# Altium schematic sheet BMS_Battery_Connections.SchDoc
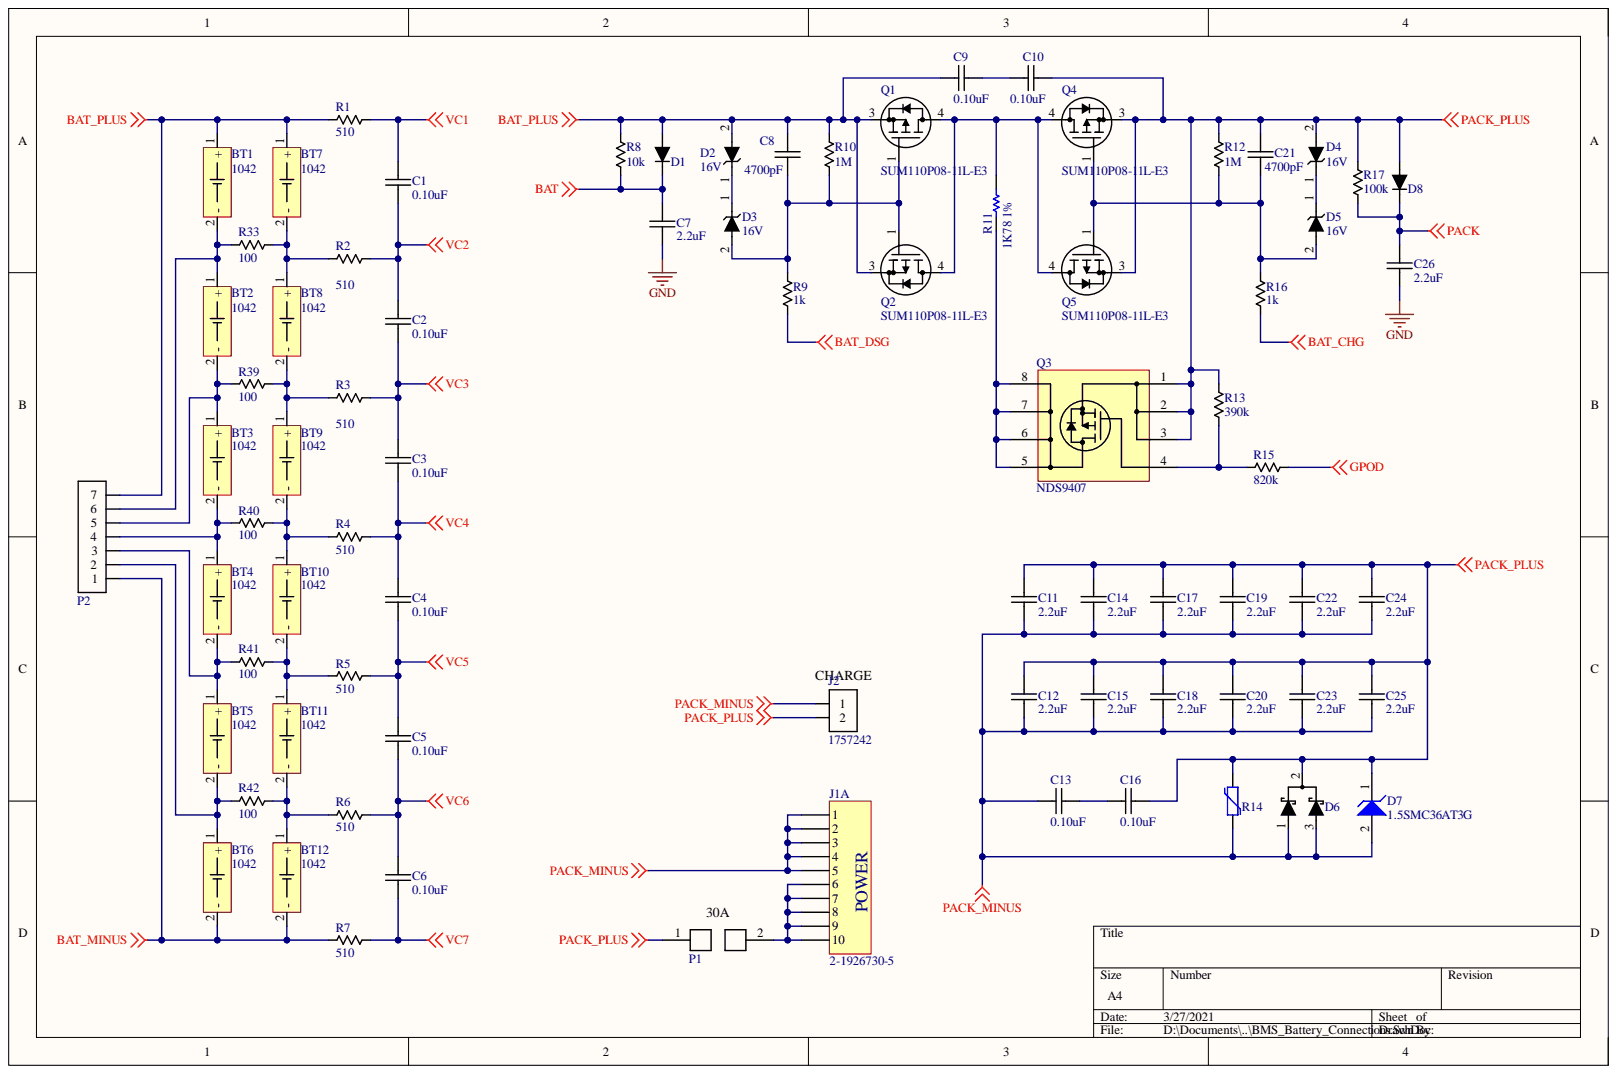
[diagram p0: BMS_Battery_Connections.SchDoc]

## Parts
BT1  1042  [KEYSTONE_1042]
BT2  1042  [KEYSTONE_1042]
BT3  1042  [KEYSTONE_1042]
BT4  1042  [KEYSTONE_1042]
BT5  1042  [KEYSTONE_1042]
BT6  1042  [KEYSTONE_1042]
BT7  1042  [KEYSTONE_1042]
BT8  1042  [KEYSTONE_1042]
BT9  1042  [KEYSTONE_1042]
BT10  1042  [KEYSTONE_1042]
BT11  1042  [KEYSTONE_1042]
BT12  1042  [KEYSTONE_1042]
C1  0.10uF  [chip_0805]
C2  0.10uF  [chip_0805]
C3  0.10uF  [chip_0805]
C4  0.10uF  [chip_0805]
C5  0.10uF  [chip_0805]
C6  0.10uF  [chip_0805]
C7  2.2uF  [chip_1210]
C8  4700pF  [chip_0805]
C9  0.10uF  [chip_0805]
C10  0.10uF  [chip_0805]
C11  2.2uF  [chip_1210]
C12  2.2uF  [chip_1210]
C13  0.10uF  [chip_0805]
C14  2.2uF  [chip_1210]
C15  2.2uF  [chip_1210]
C16  0.10uF  [chip_0805]
C17  2.2uF  [chip_1210]
C18  2.2uF  [chip_1210]
C19  2.2uF  [chip_1210]
C20  2.2uF  [chip_1210]
C21  4700pF  [chip_0805]
C22  2.2uF  [chip_1210]
C23  2.2uF  [chip_1210]
C24  2.2uF  [chip_1210]
C25  2.2uF  [chip_1210]
C26  2.2uF  [chip_1210]
D1  diode_0  [sod123_0]  (value not drawn)
D2  16V  [sod523_0]
D3  16V  [sod523_0]
D4  16V  [sod523_0]
D5  16V  [sod523_0]
D6  V40PWM10C-M3/I  [DPAK_SLIM]  (value not drawn)
D7  1.5SMC36AT3G  [ONSC-SMC-2-403-03_V]
D8  diode_0  [sod123_0]  (value not drawn)
J1  2-1926730-5  [TE_CONN_2-1926730-5]  (part A)
J2  1757242  [PHOENIX_1757242]
P1  conn-fuse-holder_0  [FUSE_HOLDER_KEYSTONE_3557-2]  (value not drawn)
P2  conn-7x1_0  [HDDR_7x1_0]  (value not drawn)
Q1  SUM110P08-11L-E3  [D2PAK-3_1]
Q2  SUM110P08-11L-E3  [D2PAK-3_1]
Q3  NDS9407  [SO-8]
Q4  SUM110P08-11L-E3  [D2PAK-3_1]
Q5  SUM110P08-11L-E3  [D2PAK-3_1]
R1  510  [chip_0805]
R2  510  [chip_0805]
R3  510  [chip_0805]
R4  510  [chip_0805]
R5  510  [chip_0805]
R6  510  [chip_0805]
R7  510  [chip_0805]
R8  10k  [chip_0805]
R9  1k  [chip_0805]
R10  1M  [chip_0805]
R11  1K78 1%  [RESC2512(6432)_L]
R12  1M  [chip_0805]
R13  390k  [chip_0805]
R14  MOV_0  [chip_1210]  (value not drawn)
R15  820k  [chip_0805]
R16  1k  [chip_0805]
R17  100k  [chip_0805]
R33  100  [chip_0805]
R39  100  [chip_0805]
R40  100  [chip_0805]
R41  100  [chip_0805]
R42  100  [chip_0805]

## Nets
BAT: C7.1 D1.1 R8.2
BAT_CHG: R16.2
BAT_DSG: R9.2
BAT_MINUS: BT6.2 BT12.2 P2.1 R7.1
BAT_PLUS: BT1.1 BT7.1 C8.1 C9.1 D1.2 D2.2 P2.7 Q1.3 Q2.3 R1.1 R8.1 R10.1
GND: C7.2 C26.2
GPOD: R15.2
PACK: C26.1 D8.1 R17.2
PACK_MINUS: C11.2 C12.2 C13.2 C14.2 C15.2 C17.2 C18.2 C19.2 C20.2 C22.2 C23.2 C24.2 C25.2 D6.1 D6.3 D7.2 J1.1 J1.2 J1.3 J1.4 J1.5 J2.1 R14.1
PACK_PLUS: C10.2 C11.1 C12.1 C14.1 C15.1 C16.1 C17.1 C18.1 C19.1 C20.1 C21.1 C22.1 C23.1 C24.1 C25.1 D4.2 D6.2 D7.1 D8.2 J2.2 P1.1 Q3.1 Q3.2 Q3.3 Q4.3 Q5.3 R12.1 R13.1 R14.2 R17.1
VC1: C1.1 R1.2
VC2: C1.2 C2.1 R2.2
VC3: C2.2 C3.1 R3.2
VC4: C3.2 C4.1 R4.2
VC5: C4.2 C5.1 R5.2
VC6: C5.2 C6.1 R6.2
VC7: C6.2 R7.2
NetBT1_2: BT1.2 BT2.1 P2.6 R33.1
NetBT2_2: BT2.2 BT3.1 P2.5 R39.1
NetBT3_2: BT3.2 BT4.1 P2.4 R40.1
NetBT4_2: BT4.2 BT5.1 P2.3 R41.1
NetBT5_2: BT5.2 BT6.1 P2.2 R42.1
NetBT7_2: BT7.2 BT8.1 R2.1 R33.2
NetBT8_2: BT8.2 BT9.1 R3.1 R39.2
NetBT9_2: BT9.2 BT10.1 R4.1 R40.2
NetBT10_2: BT10.2 BT11.1 R5.1 R41.2
NetBT11_2: BT11.2 BT12.1 R6.1 R42.2
NetC8_2: C8.2 D3.2 Q1.1 Q2.1 R9.1 R10.2
NetC9_2: C9.2 C10.1
NetC13_1: C13.1 C16.2
NetC21_2: C21.2 D5.2 Q4.1 Q5.1 R12.2 R16.1
NetD2_1: D2.1 D3.1
NetD4_1: D4.1 D5.1
NetJ1_6: J1.6 J1.7 J1.8 J1.9 J1.10 P1.2
NetQ1_4: Q1.4 Q2.4 Q4.4 Q5.4 R11.2
NetQ3_4: Q3.4 R13.2 R15.1
NetQ3_5: Q3.5 Q3.6 Q3.7 Q3.8 R11.1

## Library data of the parts
BT1-BT12 = CONN-00077: Battery Holder, 18650, SMD; Manufacturer: Keystone; Levels: 1; Positions per level: 2; Component Kind: Standard; Cost: 2.76; Library Name: Connectors$.IntLib; Manufacturer PN: 1042; Part Number: CONN-00077; Type: Battery Holder
C1-C6 C9 C10 C13 C16 = CAP-00072: CAP, 0.10uF, 10%, 50V, X7R, 0805; Manufacturer: Murata; Manufacturer PN: GRM21BR71H104KA01K; Capacitance: 0.10uF; Component Kind: Standard; Library Name: Capacitors$.IntLib; Part Number: CAP-00072; Tolerance: 10%; Type: X7R; Voltage Rating: 50V
C7 C11 C12 C14 C15 C17-C20 C22-C26 = CAP-00536: CAP, 2.2uF, ±20%, 100V, X7R, 0603; Manufacturer: TDK; Manufacturer PN: C3225X7R2A225M230AB; Capacitance: 2.2uF; Component Kind: Standard; Cost: $0.88; Library Name: Capacitors$.IntLib; Part Number: CAP-00536; Tolerance: ±20%; Type: X7R; Voltage Rating: 100V
C8 C21 = CAP-00032: CAP, 4700pF, 10%, 100V, X7R, 0805; Manufacturer: Murata; Manufacturer PN: GRM219R72A472KA01D; Capacitance: 4700pF; Component Kind: Standard; Library Name: Capacitors$.IntLib; Part Number: CAP-00032; Tolerance: 10%; Type: X7R; Voltage Rating: 100V
D1 D8 = DIO-00060: Dio, Silicon, 200mA, 1V, 100V, SOD-123; Manufacturer: ON Semi; Manufacturer PN: SMMSD4148T3G; Forward Voltage: 1.0V; Component Kind: Standard; Cost: 0.38; Current Rating: 200mA; Library Name: Diodes$.IntLib; Part Number: DIO-00060; Reverse Breakdown Voltage: 100V; Type: Silicon
D2-D5 = DIO-00082: Dio, Zener, 16V 300mW, SOD-523; Manufacturer: Nexperia; Manufacturer PN: BZX585-C16,115; Current Rating: 100mA; Forward Voltage: 1.1V; Tolerance: 5%; Component Kind: Standard; Cost: 0.25; Library Name: Diodes$.IntLib; Part Number: DIO-00082; Power Rating: 300mW; Reverse Breakdown Voltage: 16V; Type: Zener
D6 = DIO-00081: Dio, Schottky Array, Dual, Common Cathode, 100V 20A, SLIM DPAK; Manufacturer: Vishay; Forward Voltage: 0.89V; Component Kind: Standard; Cost: 1.37; Current Rating: 20A; Library Name: Diodes$.IntLib; Manufacturer PN: V40PWM10C-M3/I; Part Number: DIO-00081; Reverse Breakdown Voltage: 100V; Type: Schottky
D7 = CMP-1061-00497-1: 1500 W Peak Power Zener Transient Voltage Suppressor, Unidirectional, 2-Pin SMC, Pb-Free, Tape and Reel; Manufacturer: On Semiconductor; IR Max (uA): 5; PPK Max (W): 1500; V(BR) Typ (V): 36; Component Kind: Standard; ComponentLink1Description: Manufacturer URL; ComponentLink1URL: http://www.onsemi.com/; ComponentLink2Description: Datasheet; ComponentLink2URL: http://www.onsemi.com/pub_link/Collateral/1.5SMC6.8AT3-D.PDF; ComponentLink3Description: Package Specification; ComponentLink3URL: http://www.onsemi.com/pub_link/Collateral/403-03.PDF; DatasheetVersion: Rev. 10; Direction: Unidirectional; Library Name: Altium Content Vault; Mounting Technology: Surface Mount; PackageDescription: 2-Pin Small Molded Package, J-Bend Lead, Body 5.845 x 6.855 mm; PackageReference: SMC-2-403-03; PackageVersion: Rev. E, 08/2005; Packing: Tape and Reel; PartNumber: 1.5SMC36AT3G; RoHS: Pb-Free
J1 = CONN-00093: Backplane Header, Blades and Pins, Edge Mount Right Angle, 10 Power 25 Signal; Manufacturer: TE Connectivity; Levels: 1; Positions per level: 35; Component Kind: Standard; Cost: 11.68; Library Name: Connectors$.IntLib; Manufacturer PN: 2-1926730-5; Part Number: CONN-00093; Type: Header
J2 = CONN-00102: Terminal Blk Header, 2 Pos, 5.08mm, 12A, Right-Angle; Manufacturer: Phoenix Contact; Levels: 1; Positions per level: 2; Component Kind: Standard; Cost: 0.64; Library Name: Connectors$.IntLib; Manufacturer PN: 1757242; Part Number: CONN-00102; Type: Terminal Block
P1 = CONN-00015: Fuse Holder, 30A, 500V, LP-Mini 897, ATOF 257; Manufacturer: Keystone Electronics; Manufacturer PN: 3557-2; Current: 30; Levels: 1; Positions per level: 2; Component Kind: Standard; Cost: 1.03; Library Name: Connectors$.IntLib; Part Number: CONN-00015
P2 = CONN-00105: Header, 7x1, 0.1"; Manufacturer: Samtec; Manufacturer PN: TSW-107-07-F-S; Levels: 1; Positions per level: 7; Component Kind: Standard; Cost: 0.61; Library Name: Connectors$.IntLib; Part Number: CONN-00105; Type: Header
Q1 Q2 Q4 Q5 = XSTR-00050: XSTR, MOSFET P-Channel, 80V 110A, D2PAK; Manufacturer: Vishay Siliconix; Max Power: 375W; Type: PMOS; Vgs/Vce: 3V; Component Kind: Standard; Cost: 4.43; Library Name: Transistors$.IntLib; Manufacturer PN: SUM110P08-11L-E3; Max Current: 110A; Max Voltage: 80V; Part Number: XSTR-00050
Q3 = XSTR-00036: XSTR PFET, 3A, 60V, 2.5W, SOIC-8; Manufacturer: ON Semi; Vgs/Vce: 1.6V; Component Kind: Standard; Cost: 0.78; Library Name: Transistors$.IntLib; Manufacturer PN: NDS9407; Max Current: 3A; Max Power: 2.5W; Max Voltage: 60V; Part Number: XSTR-00036; Type: PFET
R1-R7 = RES-00240: RES SMD 510 OHM 1% 1/8W 0805; Manufacturer: Rohm Semiconductor; Manufacturer PN: KTR10EZPF5100; Power Rating: 0.125W; Component Kind: Standard; Library Name: Resistors$.IntLib; Part Number: RES-00240; Resistance: 510; Tolerance: 1%
R8 = RES-00307: RES SMD 10K OHM 1% 1/8W 0805; Manufacturer: Rohm Semiconductor; Manufacturer PN: KTR10EZPF1002; Power Rating: 0.125W; Component Kind: Standard; Library Name: Resistors$.IntLib; Part Number: RES-00307; Resistance: 10k; Tolerance: 1%
R9 R16 = RES-00361: RES SMD 1K OHM 1% 1/8W 0805; Manufacturer: Rohm Semiconductor; Manufacturer PN: KTR10EZPF1001; Power Rating: 0.125W; Component Kind: Standard; Library Name: Resistors$.IntLib; Part Number: RES-00361; Resistance: 1k; Tolerance: 1%
R10 R12 = RES-00363: RES SMD 1M OHM 1% 1/8W 0805; Manufacturer: Rohm Semiconductor; Manufacturer PN: KTR10EZPF1004; Power Rating: 0.125W; Component Kind: Standard; Library Name: Resistors$.IntLib; Part Number: RES-00363; Resistance: 1M; Tolerance: 1%
R11 = CMP-1018-00536-1: 1K78 1W 1% 2512 (6432 Metric)  SMD; Case-EIA: 2512; Case-Metric: 6432; Value: 1780.00 Ohm; Component Kind: Standard; Library Name: Altium Content Vault; Power: 1 W; Technology: SMT; Tolerance: 1 %
R13 = RES-00455: RES SMD 390K OHM 1% 1/8W 0805; Manufacturer: Rohm Semiconductor; Manufacturer PN: KTR10EZPF3903; Power Rating: 0.125W; Component Kind: Standard; Library Name: Resistors$.IntLib; Part Number: RES-00455; Resistance: 390k; Tolerance: 1%
R14 = MISC-00057: Varistor, Ceramic, 47V 300A 2J, 1210; Manufacturer: TDK; Manufacturer PN: B72530T0300K062; Component Kind: Standard; Cost: 0.65; Library Name: Misc$.IntLib; Part Number: MISC-00057
R15 = RES-00567: RES SMD 820K OHM 1% 1/8W 0805; Manufacturer: Rohm Semiconductor; Manufacturer PN: KTR10EZPF8203; Power Rating: 0.125W; Component Kind: Standard; Library Name: Resistors$.IntLib; Part Number: RES-00567; Resistance: 820k; Tolerance: 1%
R17 = RES-00302: RES SMD 100K OHM 1% 1/8W 0805; Manufacturer: Rohm Semiconductor; Manufacturer PN: KTR10EZPF1003; Power Rating: 0.125W; Component Kind: Standard; Library Name: Resistors$.IntLib; Part Number: RES-00302; Resistance: 100k; Tolerance: 1%
R33 R39-R42 = RES-00179: RES SMD 100 OHM 1% 1/8W 0805; Manufacturer: Rohm Semiconductor; Manufacturer PN: KTR10EZPF1000; Power Rating: 0.125W; Component Kind: Standard; Library Name: Resistors$.IntLib; Part Number: RES-00179; Resistance: 100; Tolerance: 1%
